# Altium schematic sheet 控制板.SchDoc(控制板)
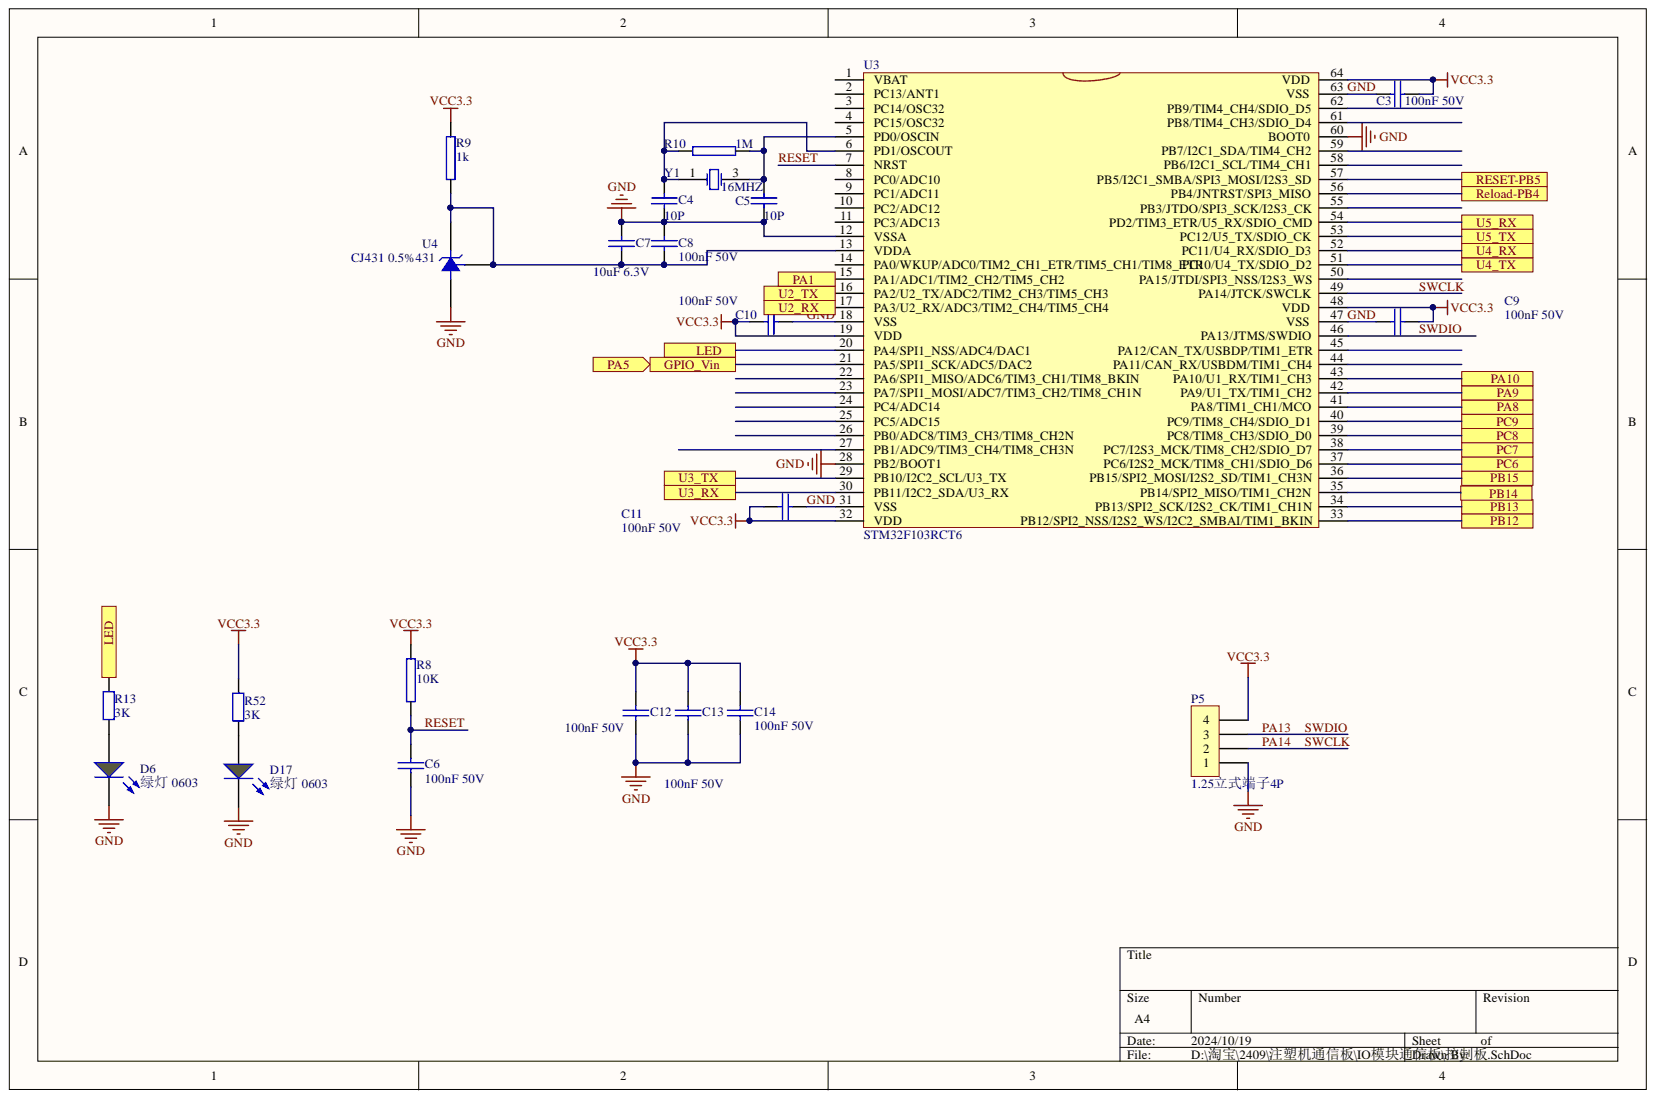
[diagram p0: 控制板.SchDoc]

## Parts
C3  100nF 50V  [0603_C]
C4  10P  [0603_C]
C5  10P  [0603_C]
C6  100nF 50V  [0603_C]
C7  10uF 6.3V  [0603_C]
C8  100nF 50V  [0603_C]
C9  100nF 50V  [0603_C]
C10  100nF 50V  [0603_C]
C11  100nF 50V  [0603_C]
C12  100nF 50V  [0603_C]
C13  100nF 50V  [0603_C]
C14  100nF 50V  [0603_C]
D6  绿灯 0603  [0603_LED_S1]
D17  绿灯 0603  Package: 0603  [0603_LED_S1]
P5  1.25立式端子4P  [1.2.5/4PM]
R8  10K  [0603_R]
R9  1k  [0603_R]
R10  1M  [0603_R]
R13  3K  [0603_R]
R52  3K  [0603_R]
U3  STM32F103RCT6  [LQFP-64_10x10x05P]
U4  CJ431 0.5%431  [SOT-23(SOT-23-3)]
Y1  16MHZ  [SMD-3225_4P]

## Ports
GPIO_Vin, LED, PA1, PA5, PA8, PA9, PA10, PB12, PB13, PB14, PB15, PC6, PC7, PC8, PC9, Reload-PB4, RESET-PB5, U2_RX, U2_TX, U3_RX, U3_TX, U4_RX, U4_TX, U5_RX, U5_TX

## Nets
VCC3.3: C3.2 C9.2 C10.1 C11.1 C12.2 C13.2 C14.2 P5.4 R8.2 R9.2 R52.2 U3.19(VDD) U3.32(VDD) U3.48(VDD) U3.64(VDD)
GND: C3.1 C4.2 C5.2 C6.2 C7.2 C8.2 C9.1 C10.2 C11.2 C12.1 C13.1 C14.1 D6.K D17.K P5.1 U3.12(VSSA) U3.18(VSS) U3.28(PB2/BOOT1) U3.31(VSS) U3.47(VSS) U3.60(BOOT0) U3.63(VSS) U4.3
GPIO_Vin: U3.21(PA5/SPI1_SCK/ADC5/DAC2) ; port GPIO_Vin, port PA5
LED: R13.2 U3.20(PA4/SPI1_NSS/ADC4/DAC1) ; port LED
PA1: U3.15(PA1/ADC1/TIM2_CH2/TIM5_CH2) ; port PA1
PA8: U3.41(PA8/TIM1_CH1/MCO) ; port PA8
PA9: U3.42(PA9/U1_TX/TIM1_CH2) ; port PA9
PA10: U3.43(PA10/U1_RX/TIM1_CH3) ; port PA10
PA13: P5.3 U3.46(PA13/JTMS/SWDIO)
PA14: P5.2 U3.49(PA14/JTCK/SWCLK)
PB12: U3.33(PB12/SPI2_NSS/I2S2_WS/I2C2_SMBAI/TIM1_BKIN) ; port PB12
PB13: U3.34(PB13/SPI2_SCK/I2S2_CK/TIM1_CH1N) ; port PB13
PB14: U3.35(PB14/SPI2_MISO/TIM1_CH2N) ; port PB14
PB15: U3.36(PB15/SPI2_MOSI/I2S2_SD/TIM1_CH3N) ; port PB15
PC6: U3.37(PC6/I2S2_MCK/TIM8_CH1/SDIO_D6) ; port PC6
PC7: U3.38(PC7/I2S3_MCK/TIM8_CH2/SDIO_D7) ; port PC7
PC8: U3.39(PC8/TIM8_CH3/SDIO_D0) ; port PC8
PC9: U3.40(PC9/TIM8_CH4/SDIO_D1) ; port PC9
Reload-PB4: U3.56(PB4/JNTRST/SPI3_MISO) ; port Reload-PB4
RESET: C6.1 R8.1 U3.7(NRST)
RESET-PB5: U3.57(PB5/I2C1_SMBA/SPI3_MOSI/I2S3_SD) ; port RESET-PB5
U2_RX: U3.17(PA3/U2_RX/ADC3/TIM2_CH4/TIM5_CH4) ; port U2_RX
U2_TX: U3.16(PA2/U2_TX/ADC2/TIM2_CH3/TIM5_CH3) ; port U2_TX
U3_RX: U3.30(PB11/I2C2_SDA/U3_RX) ; port U3_RX
U3_TX: U3.29(PB10/I2C2_SCL/U3_TX) ; port U3_TX
U4_RX: U3.52(PC11/U4_RX/SDIO_D3) ; port U4_RX
U4_TX: U3.51(PC10/U4_TX/SDIO_D2) ; port U4_TX
U5_RX: U3.54(PD2/TIM3_ETR/U5_RX/SDIO_CMD) ; port U5_RX
U5_TX: U3.53(PC12/U5_TX/SDIO_CK) ; port U5_TX
NetC4_1: C4.1 R10.2 U3.6(PD1/OSCOUT) Y1.1
NetC5_1: C5.1 R10.1 U3.5(PD0/OSCIN) Y1.3
NetC7_1: C7.1 C8.1 R9.1 U3.13(VDDA) U4.1 U4.2
NetD6_A: D6.A R13.1
NetD17_A: D17.A R52.1

Not connected: U3 1(VBAT) 2(PC13/ANT1) 3(PC14/OSC32) 4(PC15/OSC32) 8(PC0/ADC10) 9(PC1/ADC11) 10(PC2/ADC12) 11(PC3/ADC13) 14(PA0/WKUP/ADC0/TIM2_CH1_ETR/TIM5_CH1/TIM8_ETR) 22(PA6/SPI1_MISO/ADC6/TIM3_CH1/TIM8_BKIN) 23(PA7/SPI1_MOSI/ADC7/TIM3_CH2/TIM8_CH1N) 24(PC4/ADC14) 25(PC5/ADC15) 26(PB0/ADC8/TIM3_CH3/TIM8_CH2N) 27(PB1/ADC9/TIM3_CH4/TIM8_CH3N) 44(PA11/CAN_RX/USBDM/TIM1_CH4) 45(PA12/CAN_TX/USBDP/TIM1_ETR) 50(PA15/JTDI/SPI3_NSS/I2S3_WS) 55(PB3/JTDO/SPI3_SCK/I2S3_CK) 58(PB6/I2C1_SCL/TIM4_CH1) 59(PB7/I2C1_SDA/TIM4_CH2) 61(PB8/TIM4_CH3/SDIO_D4) 62(PB9/TIM4_CH4/SDIO_D5)

## Library data of the parts
C3-C14 = CAP: Component Kind: Standard; Library Name: wgh元件库.SCHLIB
D6 D17 = 0603 绿灯: 发光二极管; SuppliersPartNumber: C72043; Supplier: LC; Library Name: LED.SchLib; ComponentLink1URL: http://www.szlcsc.com/product/details_73152.html; ComponentLink1Description: 供应商链接; Component Kind: Standard
  D6: Package: 0603
P5 = CON4: Component Kind: Standard; Library Name: wgh元件库.SCHLIB
R8-R10 = RES: Component Kind: Standard; Library Name: wgh元件库.SCHLIB
R13 R52 = 0603 20KΩ (2002) 1%: 贴片电阻; SuppliersPartNumber: C4184; Supplier: LC; Package: 0603; Library Name: RES.SchLib; ComponentLink1URL: http://www.szlcsc.com/product/details_4591.html; ComponentLink1Description: 供应商链接; Component Kind: Standard
U3 = STM32F103RC: Component Kind: Standard; Library Name: wgh元件库.SCHLIB
U4 = CJ431 0.5%431_[C3113]: 电压基准; SuppliersPartNumber: C3113; Supplier: LC; Package: SOT-23; Library Name: IC.SchLib; ComponentLink1URL: http://www.szlcsc.com/product/details_3500.html; ComponentLink1Description: 供应商链接; Component Kind: Standard
Y1 = SMD-3225_4P  16MHZ 9PF 10PPM: 贴片晶振; SuppliersPartNumber: C13738; Supplier: LC; Package: SMD-3225_4P; Library Name: CrystalOscillator.SchLib; ComponentLink1URL: http://www.szlcsc.com/product/details_14393.html; ComponentLink1Description: 供应商链接; Component Kind: Standard
